# Altium schematic sheet Express.SchDoc
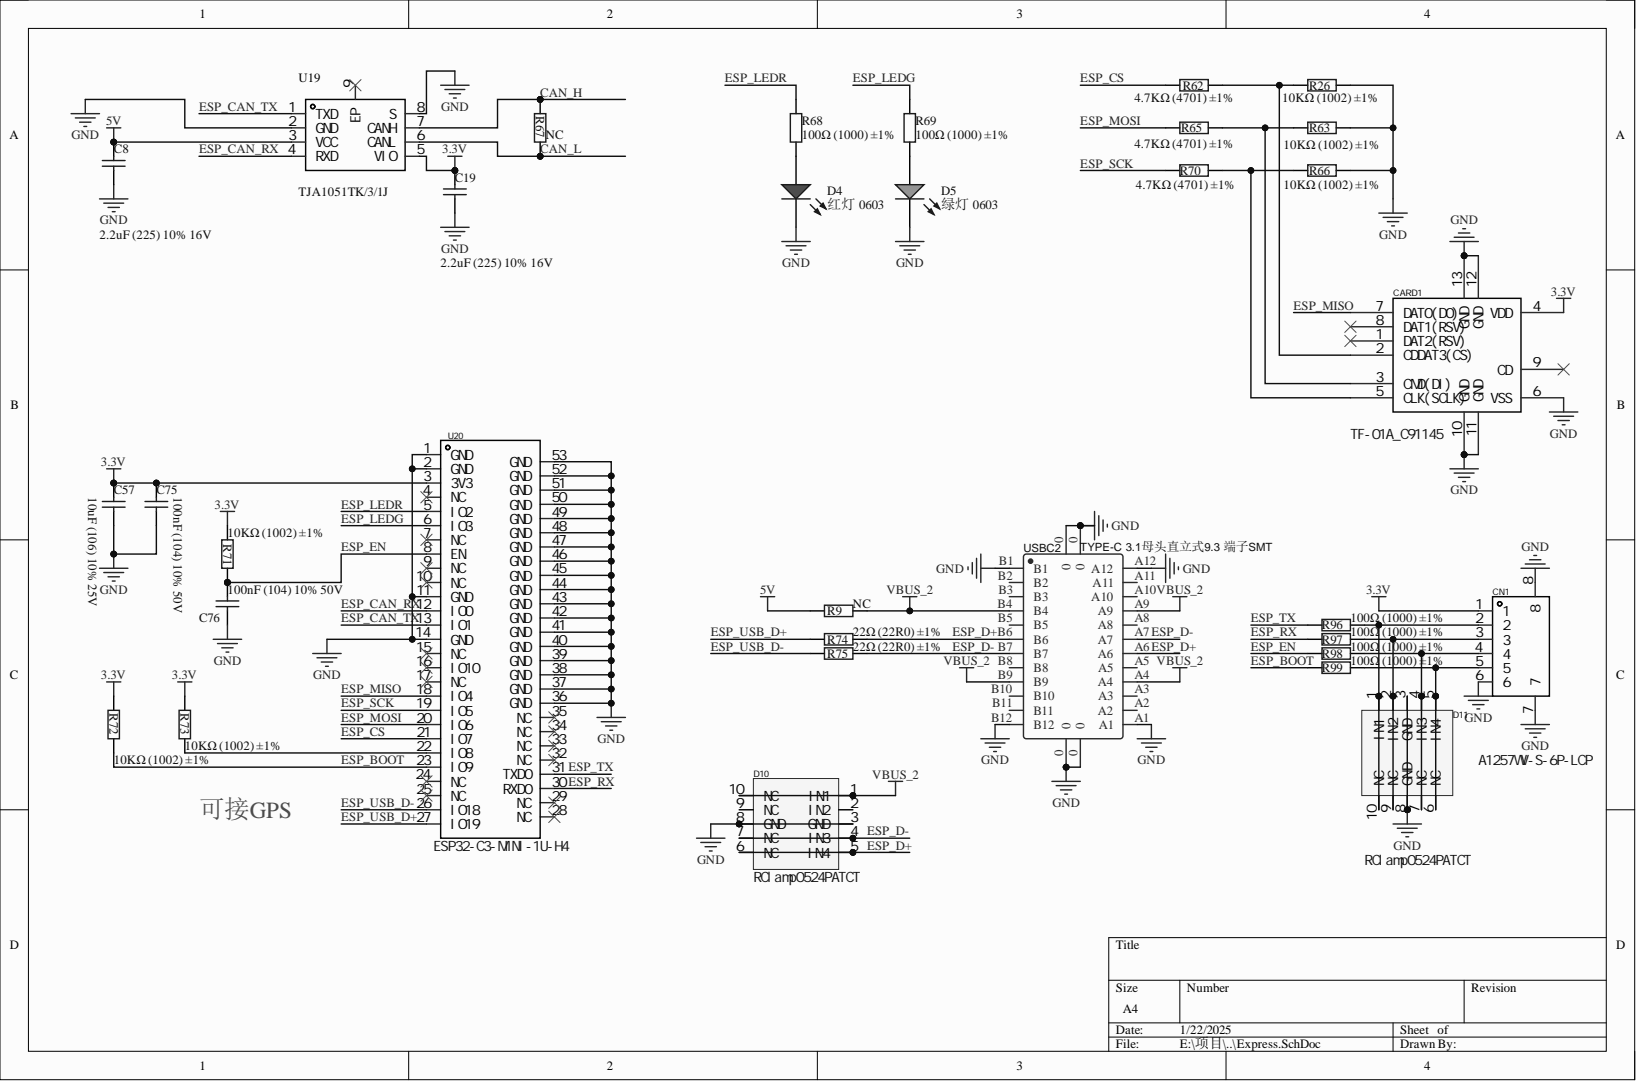
[diagram p0: Express.SchDoc]

## Parts
C8  2.2uF (225) 10% 16V  [0603_C]
C19  2.2uF (225) 10% 16V  [0603_C]
C57  10uF (106) 10% 25V  [0603_C]
C75  100nF (104) 10% 50V  [0603_C]
C76  100nF (104) 10% 50V  [0603_C]
CARD1  TF-01A_C91145  [TF-SMD_TF-01A]
CN1  A1257WV-S-6P-LCP  [CONN-SMD_A1257WV-S-6P]
D4  红灯 0603  [0603_LED_S1]
D5  绿灯 0603  Package: 0603  [0603_LED_S1]
D10  RClamp0524PATCT  [UFDFN-10]
D11  RClamp0524PATCT  [UFDFN-10]
R9  NC  [0603_R]
R26  10KΩ (1002) ±1%  [0603_R]
R62  4.7KΩ (4701) ±1%  [0603_R]
R63  10KΩ (1002) ±1%  [0603_R]
R65  4.7KΩ (4701) ±1%  [0603_R]
R66  10KΩ (1002) ±1%  [0603_R]
R67  NC  [0805_R]
R68  100Ω (1000) ±1%  [0603_R]
R69  100Ω (1000) ±1%  [0603_R]
R70  4.7KΩ (4701) ±1%  [0603_R]
R71  10KΩ (1002) ±1%  [0603_R]
R72  10KΩ (1002) ±1%  [0603_R]
R73  10KΩ (1002) ±1%  [0603_R]
R74  22Ω (22R0) ±1%  [0603_R]
R75  22Ω (22R0) ±1%  [0603_R]
R96  100Ω (1000) ±1%  [0603_R]
R97  100Ω (1000) ±1%  [0603_R]
R98  100Ω (1000) ±1%  [0603_R]
R99  100Ω (1000) ±1%  [0603_R]
U19  TJA1051TK/3/1J  [HVSON-8_L3.0-W3.0-P0.65-BL-EP]
U20  ESP32-C3-MINI-1U-H4  [WIFIM-SMD_ESP32-C3-MINI-MINI-1U]
USBC2  TYPE-C 3.1母头直立式9.3 端子SMT  [USB-C-SMD_TYPE-C-USB-2]

## Nets
3.3V: C19.1 C57.2 C75.2 CARD1.4(VDD) CN1.1 R71.1 R72.1 R73.1 U19.5(VIO) U20.3(3V3)
5V: C8.1 R9.2 U19.3(VCC)
GND: C8.2 C19.2 C57.1 C75.1 C76.1 CARD1.6(VSS) CARD1.10(GND) CARD1.11(GND) CARD1.12(GND) CARD1.13(GND) CN1.6 CN1.7 CN1.8 D4.K D5.K D10.3(GND) D10.8(GND) D11.3(GND) D11.8(GND) R26.2 R63.2 R66.2 U19.2(GND) U19.8(S) U20.1(GND) U20.2(GND) U20.11(GND) U20.14(GND) U20.36(GND) U20.37(GND) U20.38(GND) U20.39(GND) U20.40(GND) U20.41(GND) U20.42(GND) U20.43(GND) U20.44(GND) U20.45(GND) U20.46(GND) U20.47(GND) U20.48(GND) U20.49(GND) U20.50(GND) U20.51(GND) U20.52(GND) U20.53(GND) USBC2.0 USBC2.A1 USBC2.A12 USBC2.B1 USBC2.B12
VBUS_2: D10.1(IN1) D10.10(NC) R9.1 USBC2.A4 USBC2.A9 USBC2.B4 USBC2.B9
CAN_H: R67.2 U19.7(CANH)
CAN_L: R67.1 U19.6(CANL)
ESP_BOOT: R72.2 R99.2 U20.23(IO9)
ESP_CAN_RX: U19.4(RXD) U20.12(IO0)
ESP_CAN_TX: U19.1(TXD) U20.13(IO1)
ESP_CS: R62.1 U20.21(IO7)
ESP_D+: D10.5(IN4) D10.6(NC) R74.2 USBC2.A6 USBC2.B6
ESP_D-: D10.4(IN3) D10.7(NC) R75.2 USBC2.A7 USBC2.B7
ESP_EN: C76.2 R71.2 R98.2 U20.8(EN)
ESP_LEDG: R69.2 U20.6(IO3)
ESP_LEDR: R68.2 U20.5(IO2)
ESP_MISO: CARD1.7(DAT0(D0)) U20.18(IO4)
ESP_MOSI: R65.1 U20.20(IO6)
ESP_RX: R97.2 U20.30(RXD0)
ESP_SCK: R70.1 U20.19(IO5)
ESP_TX: R96.2 U20.31(TXD0)
ESP_USB_D+: R74.1 U20.27(IO19)
ESP_USB_D-: R75.1 U20.26(IO18)
NetCARD1_2: CARD1.2(CDDAT3(CS)) R26.1 R62.2
NetCARD1_3: CARD1.3(CMD(DI)) R63.1 R65.2
NetCARD1_5: CARD1.5(CLK(SCLK)) R66.1 R70.2
NetCN1_2: CN1.2 D11.1(IN1) D11.10(NC) R96.1
NetCN1_3: CN1.3 D11.2(IN2) D11.9(NC) R97.1
NetCN1_4: CN1.4 D11.4(IN3) D11.7(NC) R98.1
NetCN1_5: CN1.5 D11.5(IN4) D11.6(NC) R99.1
NetD4_A: D4.A R68.1
NetD5_A: D5.A R69.1
NetR73_2: R73.2 U20.22(IO8)

Not connected: CARD1 1(DAT2(RSV)) 8(DAT1(RSV)) 9(CD); D10 2(IN2) 9(NC); U19 9(EP); U20 4(NC) 7(NC) 9(NC) 10(NC) 15(NC) 16(IO10) 17(NC) 24(NC) 25(NC) 28(NC) 29(NC) 32(NC) 33(NC) 34(NC) 35(NC); USBC2 A2 A3 A5 A8 A10 A11 B2 B3 B5 B8 B10 B11

## Library data of the parts
C8 C19 = 0603 2.2uF (225) 10% 16V: 贴片电容; Component Kind: Standard; ComponentLink1Description: 供应商链接; ComponentLink1URL: http://www.szlcsc.com/product/details_24366.html; Library Name: Cap.SchLib; Package: 0603; Supplier: LC; SuppliersPartNumber: C23630
C57 = 0805 10uF (106) 10% 25V: 贴片电容; Component Kind: Standard; ComponentLink1Description: 供应商链接; ComponentLink1URL: http://www.szlcsc.com/product/details_16532.html; Library Name: Cap.SchLib; Package: 0805; Supplier: LC; SuppliersPartNumber: C15850
C75 C76 = 0603 100nF (104) 10% 50V: 贴片电容; Component Kind: Standard; ComponentLink1Description: 供应商链接; ComponentLink1URL: http://www.szlcsc.com/product/details_15331.html; Library Name: Cap.SchLib; Package: 0603; Supplier: LC; SuppliersPartNumber: C14663
CARD1 = TF-01A_C91145: Manufacturer: 韩国韩荣; Manufacturer Part: TF-01A; Add into BOM: yes; Card Connection Mode: 自弹式; Card Type: MicroSD卡(TF卡); Connector Type: 卡座; Component Kind: Standard; Contact Material: -; Contact Plating: -; Convert to PCB: yes; Datasheet: https://atta.szlcsc.com/upload/public/pdf/source/20190322/C91145_63CBD6FB4639A3A3EC6A9721F18AE0FB.pdf; Device: TF-01A_C91145; JLCPCB Part Class: Extended Part; LCSC Part Name: 自弹式 MicroSD卡(TF卡) 卡座; Maximum Body Height: -; Operating Temperature Range: -; Soldering Temperature(Max): -; Supplier: LCSC; Supplier Footprint: SMD; Supplier Part: C91145; Symbol: TF-01A
CN1 = A1257WV-S-6P-LCP: Manufacturer: CJT(长江连接器); Add into BOM: yes; Number of PINs Per Row: 6; Pins Structure: 1x6P; Pitch: 1.25mm; Reference Series: GH; Component Kind: Standard; Contact Material: 黄铜; Convert to PCB: yes; Datasheet: https://atta.szlcsc.com/upload/public/pdf/source/20181008/C272994_C9E89FA8A36D0009CAD30AD8C59C2782.pdf; Device: A1257WV-S-6P-LCP; JLCPCB Part Class: Extended Part; LCSC Part Name: 系列:GH 间距:1.25mm 1x6P; Manufacturer Part: A1257WV-S-6P-LCP; Mounting Style: -; Number of Pins: 6P; Number of Rows: 1; Row Spacing: -; Supplier: LCSC; Supplier Footprint: SMD,P=1.25mm; Supplier Part: C225122; Symbol: A1257WV-S-6P
D4 = 0603 红灯: 发光二极管; Component Kind: Standard; ComponentLink1Description: 供应商链接; ComponentLink1URL: http://www.szlcsc.com/product/details_2659.html; Library Name: LED.SchLib; Package: 0603; Supplier: LC; SuppliersPartNumber: C2286
D5 = 0603 绿灯: 发光二极管; Component Kind: Standard; ComponentLink1Description: 供应商链接; ComponentLink1URL: http://www.szlcsc.com/product/details_73152.html; Library Name: LED.SchLib; Supplier: LC; SuppliersPartNumber: C72043
D10 D11 = RClamp0524PATCT: Manufacturer: SEMTECH; Add into BOM: yes; Breakdown Voltage (VBR): 6V; Clamping Voltage@Ipp: 15V; Device: RClamp0524PATCT_C40960; Junction Capacitance(Cj)@1MHz: 0.8pF@1MHz; Number of Lines: 4; Peak Pulse Power(Ppp)@8/20us: 150W; Reverse Stand-Off Voltage (Vrwm): 5V; Component Kind: Standard; Convert to PCB: yes; Datasheet: https://atta.szlcsc.com/upload/public/pdf/source/20150124/1457707260739.pdf; JLCPCB Part Class: Extended Part; LCSC Part Name: RClamp0524PATCT; Library Name: DVC1124_20S.SchLib; Manufacturer Part: RClamp0524PATCT; Name: RClamp0524PATCT; Operating Temperature: -55℃~+125℃@(Tj); Supplier: LCSC; Supplier Footprint: SLP2510-8; Supplier Part: C40960; Symbol: RClamp0524PATCT
R9 = 0402 0Ω (0R0) 1%: 贴片电阻; Component Kind: Standard; ComponentLink1Description: 供应商链接; ComponentLink1URL: http://www.szlcsc.com/product/details_17853.html; Library Name: RES.SchLib; Package: 0402; Supplier: LC; SuppliersPartNumber: C17168
R26 R63 R66 R71-R73 = 0603 10KΩ (1002) 1%: 贴片电阻; Component Kind: Standard; ComponentLink1Description: 供应商链接; ComponentLink1URL: http://www.szlcsc.com/product/details_26547.html; Library Name: Miscellaneous Devices LC.IntLib; Package: 0603; Supplier: LC; SuppliersPartNumber: C25804
R62 R65 R70 = 0603 4.7KΩ (4701) 1%: 贴片电阻; Component Kind: Standard; ComponentLink1Description: 供应商链接; ComponentLink1URL: http://www.szlcsc.com/product/details_23889.html; Library Name: RES.SchLib; Package: 0603; Supplier: LC; SuppliersPartNumber: C23162
R67 = 0805 120Ω (1200) 1%: 贴片电阻; Component Kind: Standard; ComponentLink1Description: 供应商链接; ComponentLink1URL: http://www.szlcsc.com/product/details_18125.html; Library Name: RES.SchLib; Package: 0805; Supplier: LC; SuppliersPartNumber: C17437
R68 R69 R96-R99 = 0603 100Ω (1000) 1%: 贴片电阻; Component Kind: Standard; ComponentLink1Description: 供应商链接; ComponentLink1URL: http://www.szlcsc.com/product/details_23502.html; Library Name: RES.SchLib; Package: 0603; Supplier: LC; SuppliersPartNumber: C22775
R74 R75 = 0603 22Ω (22R0) 1%: 贴片电阻; Component Kind: Standard; ComponentLink1Description: 供应商链接; ComponentLink1URL: http://www.szlcsc.com/product/details_24078.html; Library Name: RES.SchLib; Package: 0603; Supplier: LC; SuppliersPartNumber: C23345
U19 = TJA1051TK/3/1J: Manufacturer: NXP(恩智浦); Add into BOM: yes; Component Kind: Standard; Convert to PCB: yes; Datasheet: https://atta.szlcsc.com/upload/public/pdf/source/20210128/C2671135_A82E49CDEFC20634F2534E8F261E286A.pdf; Device: TJA1051TK/3/1J; JLCPCB Part Class: Extended Part; LCSC Part Name: TJA1051TK/3/1J; Manufacturer Part: TJA1051TK/3/1J; Name: TJA1051TK/3/1J; PCB3D: HVSON-8_L3.0-W3.0-H0.85-P0.65-BL-EP; Supplier: LCSC; Supplier Footprint: HVSON-8-EP(3x3); Supplier Part: C2875699; Symbol: TJA1051TK/3/1J
U20 = ESP32-C3-MINI-1-H4: Manufacturer: ESPRESSIF(乐鑫); Add into BOM: yes; Antenna Type: 板载PCB天线; Name: 2.4GHz; Operating Voltage: 3.3V; Output Power: 20.5dBm; Sensitivity: -96dBm; Support Interface: SPI; Symbol: ESP32-C3-MINI-1-H4(4MB); Value: 2.4GHz; Component Kind: Standard; Convert to PCB: yes; Core Processor: -; Datasheet: https://atta.szlcsc.com/upload/public/pdf/source/20211222/4A6E563D9536B67D492463D36DD57C1D.pdf; Device: ESP32-C3-MINI-1-H4; JLCPCB Part Class: Extended Part; LCSC Part Name: ESP32-C3-MINI-1-H4; Manufacturer Part: ESP32-C3-MINI-1-H4; Operating Temperature: -40℃~+85℃; PCB3D: WIFIM-SMD_ESP32-C3-MINI-1; Receive  Current: -; Send  Current: -; Supplier: LCSC; Supplier Footprint: SMD,13.2x16.6mm; Supplier Part: C2934569; Wireless Standard: -
USBC2 = TYPE-C-3.1-Female-TH-9.3: BOM_Manufacturer: LCSC; BOM_Manufacturer Part: -; BOM_Supplier: LCSC; BOM_Supplier Part: C168703; Component Kind: Standard; spicePre: U; spiceSymbolName: TYPE-C-3.1-Female-TH-9.3; Contributor: LCSC
